# Altium schematic sheet RS232_M_V1.SchDoc
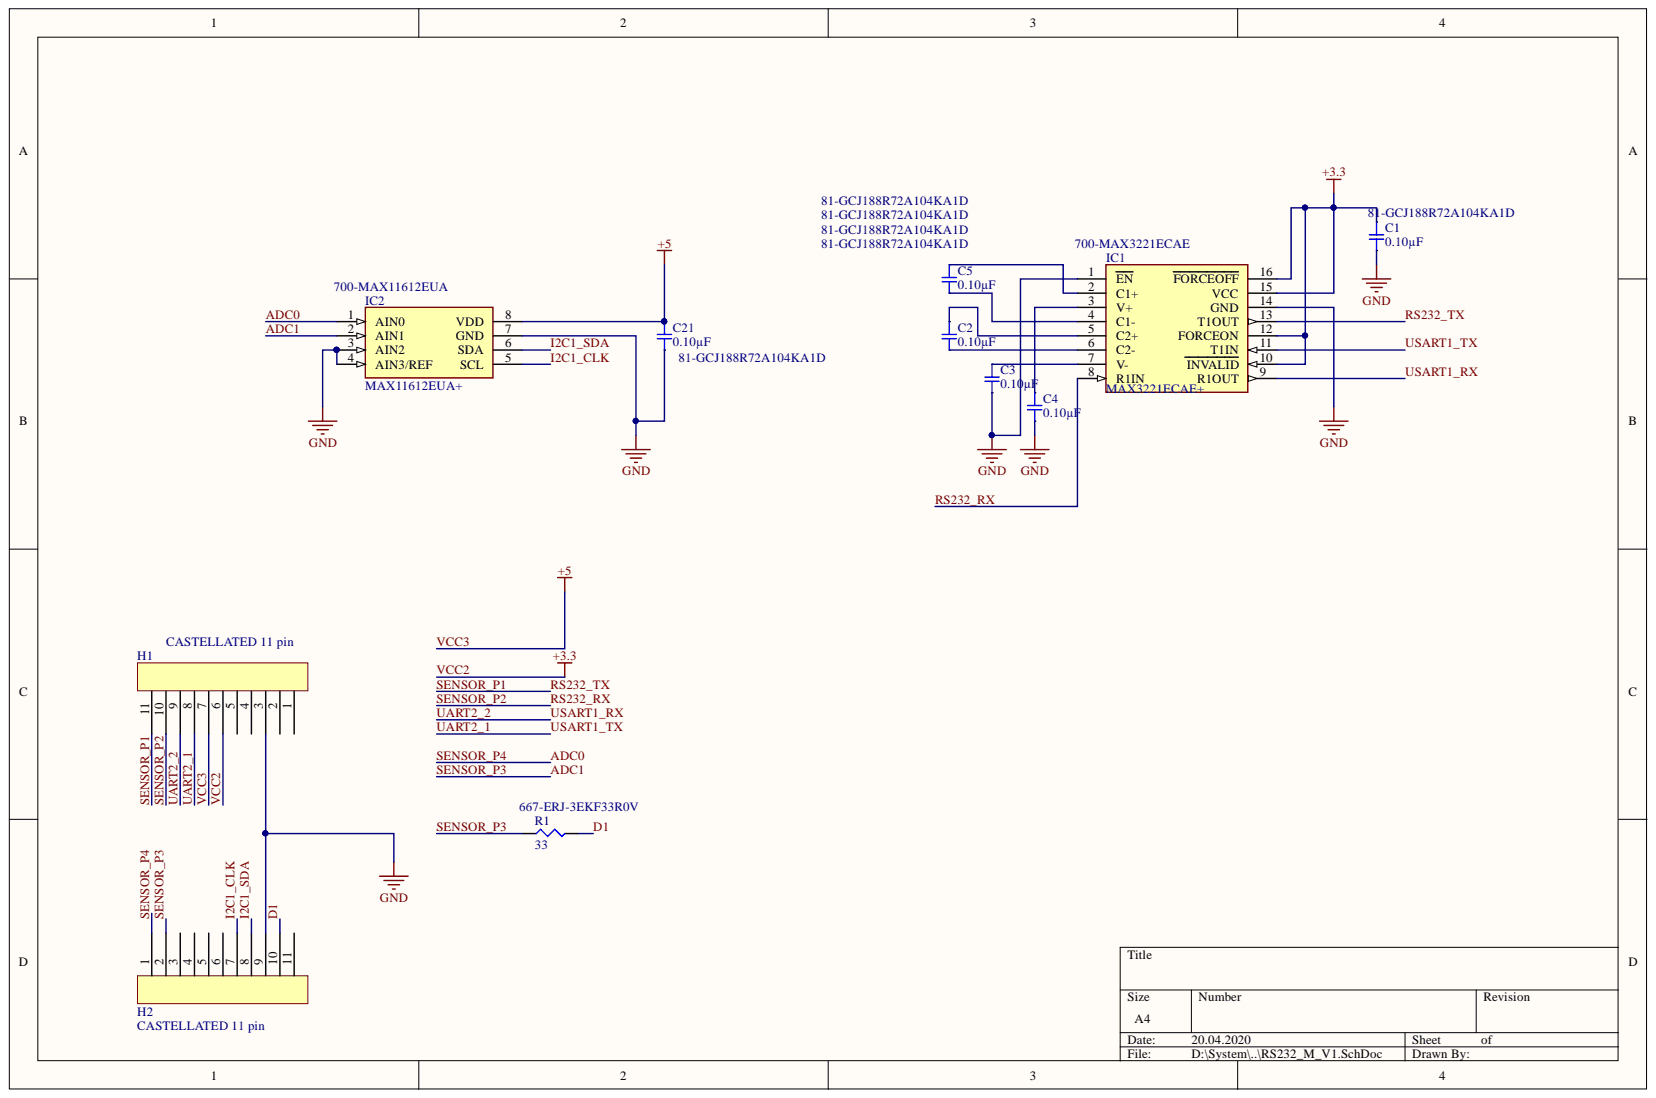
[diagram p0: RS232_M_V1.SchDoc]

## Parts
C1  0.10µF  Supplier Part Number 1: 81-GCJ188R72A104KA1D  [SMD-0603C]
C2  0.10µF  [SMD-0603C]
C3  0.10µF  [SMD-0603C]
C4  0.10µF  [SMD-0603C]
C5  0.10µF  Supplier Part Number 1: 81-GCJ188R72A104KA1D  [SMD-0603C]
C21  0.10µF  Supplier Part Number 1: 81-GCJ188R72A104KA1D  [SMD-0603C]
H1  CASTELLATED 11 pin  [CASTELLATED 11 pin]
H2  CASTELLATED 11 pin  [CASTELLATED 11 pin]
IC1  MAX3221ECAE+  Supplier Part Number 1: 700-MAX3221ECAE  [SOP65P778X199-16N]
IC2  MAX11612EUA+  Supplier Part Number 1: 700-MAX11612EUA  [SOP65P490X110-8N]
R1  33  Supplier Part Number 1: 667-ERJ-3EKF33R0V  [SMD-0603-RES]

## Nets
GND: C1.2 C3.2 C4.2 C21.2 H1.3 H2.9 IC1.1(EN) IC1.14(GND) IC2.3(AIN2) IC2.4(AIN3/REF) IC2.7(GND)
ADC0: H2.1 IC2.1(AIN0)
ADC1: H2.2 IC2.2(AIN1) R1.1
D1: H2.10 R1.2
I2C1_CLK: H2.7 IC2.5(SCL)
I2C1_SDA: H2.8 IC2.6(SDA)
RS232_RX: H1.10 IC1.8(R1IN)
RS232_TX: H1.11 IC1.13(T1OUT)
UART2_1: H1.8 IC1.11(T1IN)
UART2_2: H1.9 IC1.9(R1OUT)
VCC2: C1.1 H1.6 IC1.10(INVALID) IC1.12(FORCEON) IC1.15(VCC) IC1.16(FORCEOFF)
VCC3: C21.1 H1.7 IC2.8(VDD)
NetC2_1: C2.1 IC1.5(C2+)
NetC2_2: C2.2 IC1.6(C2-)
NetC3_1: C3.1 IC1.7(V-)
NetC4_1: C4.1 IC1.3(V+)
NetC5_1: C5.1 IC1.2(C1+)
NetC5_2: C5.2 IC1.4(C1-)

Not connected: H1 1 2 4 5; H2 3 4 5 6 11

## Library data of the parts
C1-C5 C21 = GCJ188R72A104KA01D: CAP CER 0.1UF 100V X7R 0603; Manufacturer: Murata Electronics North America; @ qty: 0; Database Table Name: capacitor_murata_0603$; Minimum Quantity: 1; Tolerance: ±10%; Unit Price (USD): 0,23; Applications: Automotive, Boardflex Sensitive; Component Kind: Standard; Datasheets: http://www.murata.com/~/media/webrenewal/support/library/catalog/products/capacitor/mlcc/c03e.ashx; Digi-Key Part Number: 490-13420-1-ND; Factory Stock: 0; Features: Soft Termination; Height - Seated (Max): -; Image: //media.digikey.com/Renders/Murata%20Renders/0603%28LQG18%29.jpg; Lead Spacing: -; Lead Style: -; Library Name: cap_murata.DbLib; Mounting Type: Surface Mount, MLCC; Operating Temperature: -55°C ~ 125°C; Package / Case: 0603 (1608 Metric); Packaging: Cut Tape (CT); Part Number: GCJ188R72A104KA01D; Part Status: Active; Quantity Available: 2728; Ratings: AEC-Q200; Series: GCJ; Size / Dimension: 0.063" L x 0.031" W (1.60mm x 0.80mm); Supplier 1: Mouser; Temperature Coefficient: X7R; Thickness (Max): 0.035" (0.90mm); Value: 0.10µF; Voltage: 100V
  C2-C4: Supplier Part Number 1: 81-GCJ188R72A104KA1D
IC1 = MAX3221ECAE+: Integrated Circuit; Manufacturer_Name: Maxim Integrated; Component Kind: Standard; Datasheet Link: http://datasheets.maximintegrated.com/en/ds/MAX3221E-MAX3243E.pdf; Height: 1.99mm; Manufacturer_Part_Number: MAX3221ECAE+; Supplier 1: Mouser
IC2 = MAX11612EUA+: Integrated Circuit; Component Kind: Standard; Library Name: SamacSys.SchLib; Supplier 1: Mouser
R1 = ERJ-3EKF33R0V: RES SMD 33 OHM 1% 1/10W 0603; Manufacturer: Panasonic Electronic Components; @ qty: 1500; Factory Stock: 0; Minimum Quantity: 1; Power (Watts): 0.1W, 1/10W; Temperature Coefficient: ±100ppm/°C; Unit Price (USD): 0.00379; Component Kind: Standard; Composition: Thick Film; Datasheets: http://industrial.panasonic.com/www-cgi/jvcr13pz.cgi?E+PZ+3+AOA0002+ERJ3EKF33R0V+7+WW; Digi-Key Part Number: P33.0HCT-ND; Failure Rate: -; Features: Automotive AEC-Q200; Height - Seated (Max): 0.022" (0.55mm); Image: //media.digikey.com/Photos/Panasonic%20Photos/MFG_ERJ-P6W%20Series.jpg; Library Name: Panasonic_ERJ_0603.IntLib; Number of Terminations: 2; Operating Temperature: -55°C ~ 155°C; Package / Case: 0603 (1608 Metric); Packaging: Cut Tape (CT); Part Number: ERJ-3EKF33R0V; Part Status: Active; Quantity Available: 1306721; Series: ERJ; Size / Dimension: 0.063" L x 0.031" W (1.60mm x 0.80mm); Supplier 1: Mouser; Supplier Device Package: 0603; Tolerance: ±1%; Value: 33
H1 H2 = CASTELLATED 11 pin: Component Kind: Standard; Library Name: USIB.SchLib
